# Altium schematic sheet 5.04.51805A02_STMMCU.SchDoc(5.04.51805A02_STMMCU)
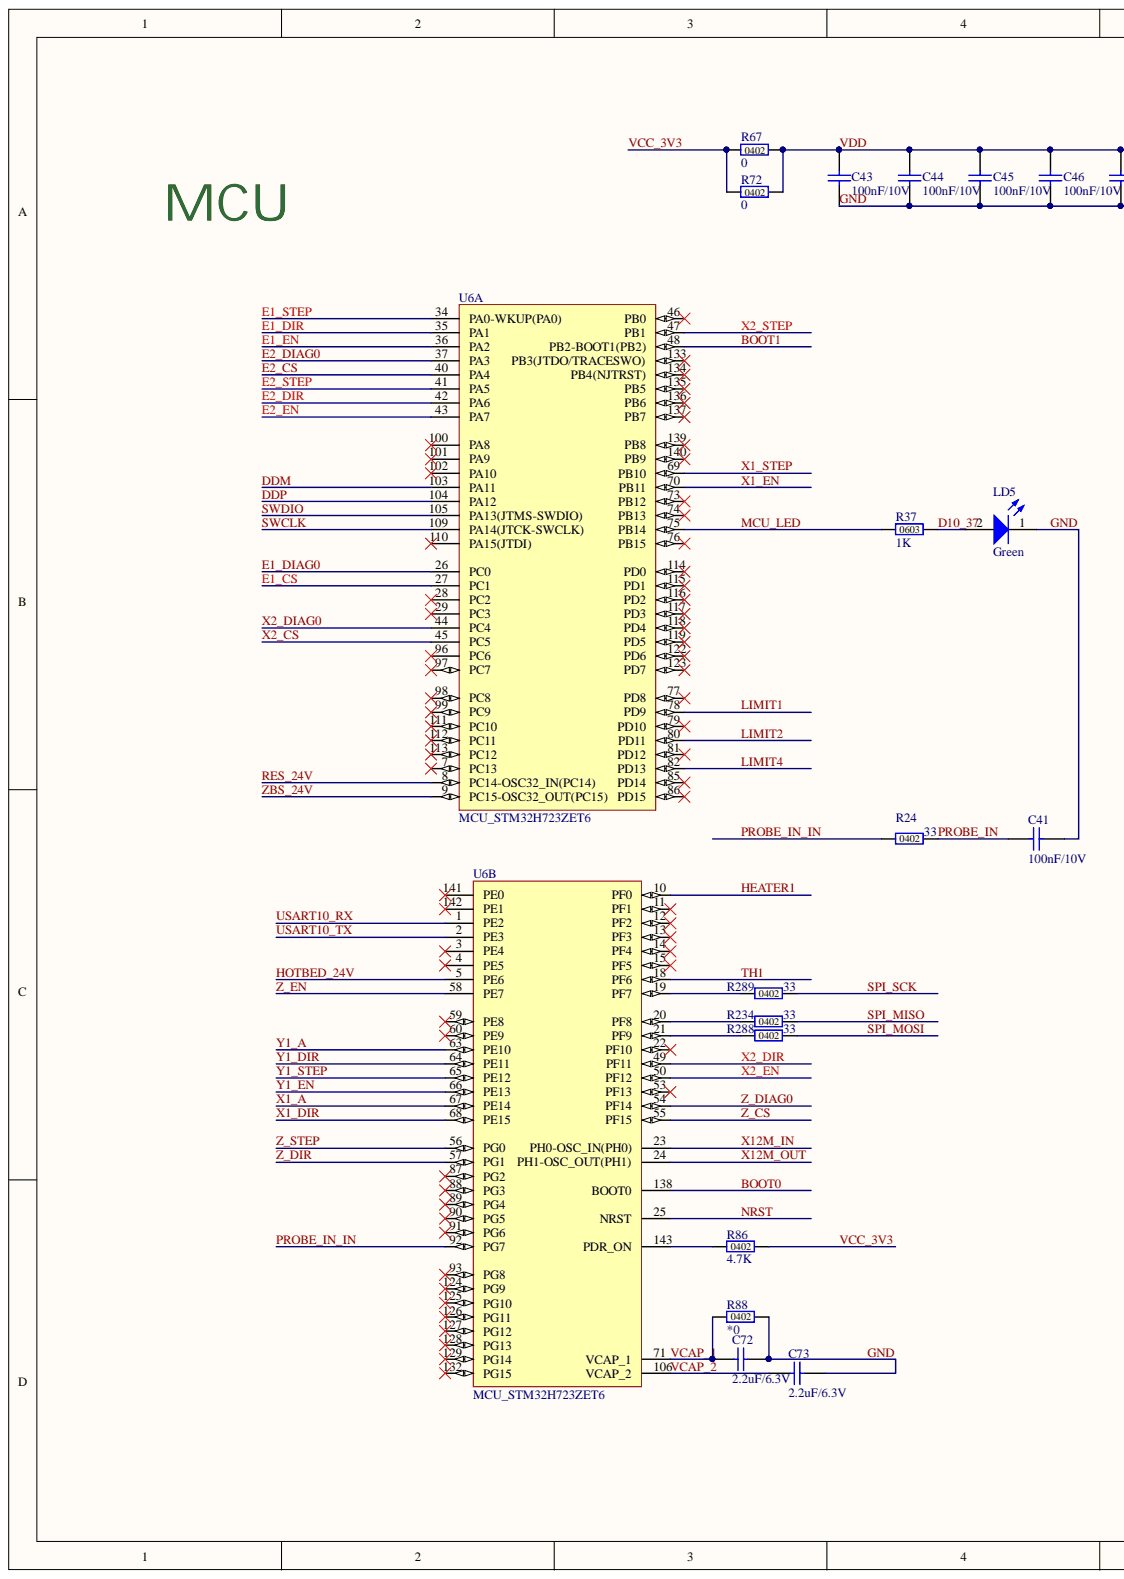
[diagram p0: 5.04.51805A02_STMMCU.SchDoc, left]
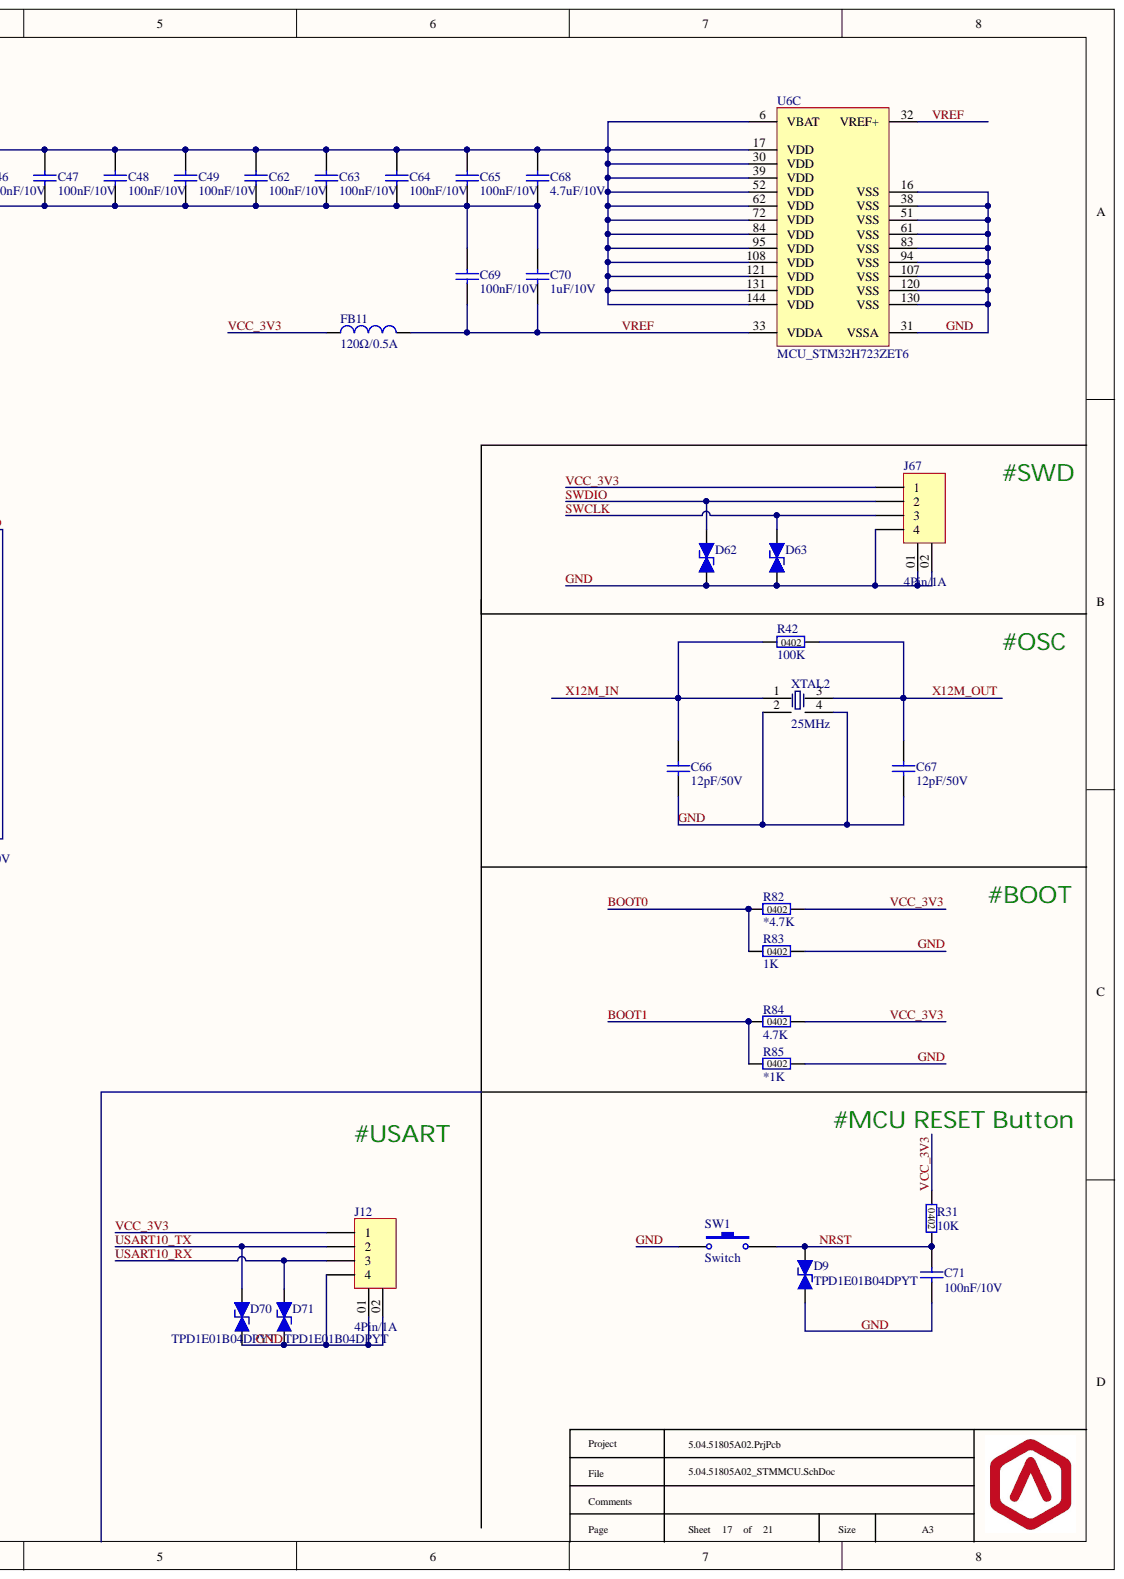
[diagram p1: 5.04.51805A02_STMMCU.SchDoc, right]

## Parts
C41  100nF/10V  [C0402]
C43  100nF/10V  [C0402]
C44  100nF/10V  [C0402]
C45  100nF/10V  [C0402]
C46  100nF/10V  [C0402]
C47  100nF/10V  [C0402]
C48  100nF/10V  [C0402]
C49  100nF/10V  [C0402]
C62  100nF/10V  [C0402]
C63  100nF/10V  [C0402]
C64  100nF/10V  [C0402]
C65  100nF/10V  [C0402]
C66  12pF/50V  [C0603]
C67  12pF/50V  [C0603]
C68  4.7uF/10V  [C0402]
C69  100nF/10V  [C0402]
C70  1uF/10V  [C0402]
C71  100nF/10V  [C0402]
C72  2.2uF/6.3V  [C0402]
C73  2.2uF/6.3V  [C0402]
D9  TPD1E01B04DPYT  [TVS_0402]
D62  TPD1E01B04DPYT  [TVS_0402]
D63  TPD1E01B04DPYT  [TVS_0402]
D70  TPD1E01B04DPYT  [TVS_0402]
D71  TPD1E01B04DPYT  [TVS_0402]
FB11  120Ω/0.5A  [L0402]
J12  4Pin/1A  [BM04B-GHS-TBT(LF)(SN)]
J67  4Pin/1A  [BM04B-GHS-TBT(LF)(SN)]
LD5  Green  [LED0603_2]
R24  33  [R0402]
R31  10K  [R0402]
R37  1K  [R0603]
R42  100K  [R0402]
R67  0  [R0402]
R72  0  [R0402]
R82  *4.7K  [R0402]
R83  1K  [R0402]
R84  4.7K  [R0402]
R85  *1K  [R0402]
R86  4.7K  [R0402]
R88  *0  [R0402]
R234  33  [R0402]
R288  33  [R0402]
R289  33  [R0402]
SW1  Switch  [TS-021]
U6  MCU_STM32H723ZET6  [LQFP144_N]  (parts A,B,C)
XTAL2  25MHz  [XTAL_24MHz]

## Nets
BOOT0: R82.1 R83.1 U6.138(BOOT0)
BOOT1: R84.1 R85.1 U6.48(PB2-BOOT1(PB2))
D10_37: LD5.2 R37.2
DDM: U6.103(PA11)
DDP: U6.104(PA12)
E1_CS: U6.27(PC1)
E1_DIAG0: U6.26(PC0)
E1_DIR: U6.35(PA1)
E1_EN: U6.36(PA2)
E1_STEP: U6.34(PA0-WKUP(PA0))
E2_CS: U6.40(PA4)
E2_DIAG0: U6.37(PA3)
E2_DIR: U6.42(PA6)
E2_EN: U6.43(PA7)
E2_STEP: U6.41(PA5)
GND: C41.1 C43.1 C44.1 C45.1 C46.1 C47.1 C48.1 C49.1 C62.1 C63.1 C64.1 C65.1 C66.1 C67.1 C68.1 C69.2 C70.2 C71.1 C72.1 C73.1 D9.1 D62.1 D63.1 D70.1 D71.1 J12.01 J12.02 J12.4 J67.01 J67.02 J67.4 LD5.1 R83.2 R85.2 R88.2 SW1.1 U6.16(VSS) U6.31(VSSA) U6.38(VSS) U6.51(VSS) U6.61(VSS) U6.83(VSS) U6.94(VSS) U6.107(VSS) U6.120(VSS) U6.130(VSS) XTAL2.2 XTAL2.4
HEATER1: U6.10(PF0)
HOTBED_24V: U6.5(PE6)
LIMIT1: U6.78(PD9)
LIMIT2: U6.80(PD11)
LIMIT4: U6.82(PD13)
MCU_LED: R37.1 U6.75(PB14)
NRST: C71.2 D9.2 R31.2 SW1.2 U6.25(NRST)
PROBE_IN: C41.2 R24.2
PROBE_IN_IN: R24.1 U6.92(PG7)
RES_24V: U6.8(PC14-OSC32_IN(PC14))
SPI_MISO: R234.2
SPI_MOSI: R288.2
SPI_SCK: R289.2
SWCLK: D63.2 J67.3 U6.109(PA14(JTCK-SWCLK))
SWDIO: D62.2 J67.2 U6.105(PA13(JTMS-SWDIO))
TH1: U6.18(PF6)
USART10_RX: D71.2 J12.3 U6.1(PE2)
USART10_TX: D70.2 J12.2 U6.2(PE3)
VCAP_1: C72.2 R88.1 U6.71(VCAP_1)
VCAP_2: C73.2 U6.106(VCAP_2)
VCC_3V3: FB11.1 J12.1 J67.1 R31.1 R67.1 R72.1 R82.2 R84.2 R86.2
VDD: C43.2 C44.2 C45.2 C46.2 C47.2 C48.2 C49.2 C62.2 C63.2 C64.2 C65.2 C68.2 R67.2 R72.2 U6.6(VBAT) U6.17(VDD) U6.30(VDD) U6.39(VDD) U6.52(VDD) U6.62(VDD) U6.72(VDD) U6.84(VDD) U6.95(VDD) U6.108(VDD) U6.121(VDD) U6.131(VDD) U6.144(VDD)
VREF: C69.1 C70.1 FB11.2 U6.32(VREF+) U6.33(VDDA)
X1_A: U6.67(PE14)
X1_DIR: U6.68(PE15)
X1_EN: U6.70(PB11)
X1_STEP: U6.69(PB10)
X2_CS: U6.45(PC5)
X2_DIAG0: U6.44(PC4)
X2_DIR: U6.49(PF11)
X2_EN: U6.50(PF12)
X2_STEP: U6.47(PB1)
X12M_IN: C66.2 R42.1 U6.23(PH0-OSC_IN(PH0)) XTAL2.1
X12M_OUT: C67.2 R42.2 U6.24(PH1-OSC_OUT(PH1)) XTAL2.3
Y1_A: U6.63(PE10)
Y1_DIR: U6.64(PE11)
Y1_EN: U6.66(PE13)
Y1_STEP: U6.65(PE12)
Z_CS: U6.55(PF15)
Z_DIAG0: U6.54(PF14)
Z_DIR: U6.57(PG1)
Z_EN: U6.58(PE7)
Z_STEP: U6.56(PG0)
ZBS_24V: U6.9(PC15-OSC32_OUT(PC15))
NetR86_1: R86.1 U6.143(PDR_ON)
NetR234_1: R234.1 U6.20(PF8)
NetR288_1: R288.1 U6.21(PF9)
NetR289_1: R289.1 U6.19(PF7)
N00843:

Not connected: U6 3(PE4) 4(PE5) 7(PC13) 11(PF1) 12(PF2) 13(PF3) 14(PF4) 15(PF5) 22(PF10) 28(PC2) 29(PC3) 46(PB0) 53(PF13) 59(PE8) 60(PE9) 73(PB12) 74(PB13) 76(PB15) 77(PD8) 79(PD10) 81(PD12) 85(PD14) 86(PD15) 87(PG2) 88(PG3) 89(PG4) 90(PG5) 91(PG6) 93(PG8) 96(PC6) 97(PC7) 98(PC8) 99(PC9) 100(PA8) 101(PA9) 102(PA10) 110(PA15(JTDI)) 111(PC10) 112(PC11) 113(PC12) 114(PD0) 115(PD1) 116(PD2) 117(PD3) 118(PD4) 119(PD5) 122(PD6) 123(PD7) 124(PG9) 125(PG10) 126(PG11) 127(PG12) 128(PG13) 129(PG14) 132(PG15) 133(PB3(JTDO/TRACESWO)) 134(PB4(NJTRST)) 135(PB5) 136(PB6) 137(PB7) 139(PB8) 140(PB9) 141(PE0) 142(PE1)

## Library data of the parts
C41 C43-C49 C62-C65 C69 C71 = C0402_100nF_10V: Ceramic Capacitor, 100nF, ±10%, 10V, -55°C~125°C, C0402; Manufacturer1: MuRata; ManufacturerPartNumber1: GRM155R71A104KA01D; Code: 5161C104; Component Kind: Standard; Library Name: Raise3D_Capacitor.IntLib
C66 C67 = C0603_10pF_50V: Ceramic Capacitor, 12pF, ±5%, 50V, -55°C~125°C, C0603; Manufacturer1: FH; ManufacturerPartNumber1: 0603CG120J500NT; Code: 5192C100; Component Kind: Standard; Library Name: Raise3D_Capacitor.IntLib
C68 = C0402_4.7uF_10V: Ceramic Capacitor, 4.7uF, ±20%, 10V, -55°C~85°C, C0402; Manufacturer1: MuRata; ManufacturerPartNumber1: GRM155R61A475MEAAD; Code: 5161C475; Component Kind: Standard; Library Name: Raise3D_Capacitor.IntLib
C70 = C0402_1uF_10V: Ceramic Capacitor, 1uF, ±10%, 10V, -55°C~125°C, C0402; Manufacturer1: Yageo; ManufacturerPartNumber1: CC0402KRX5R6BB105; Code: 5161C105; Component Kind: Standard; Library Name: Raise3D_Capacitor.IntLib
C72 C73 = C0402_2.2uF_6.3V: Ceramic Capacitor, 2.2uF, ±10%, 6.3V, -55°C~85°C, C0402; Manufacturer1: Yageo; ManufacturerPartNumber1: CC0402KRX5R5BB225; Code: 5151C225; Component Kind: Standard; Library Name: Raise3D_Capacitor.IntLib
D9 D62 D63 D70 D71 = TVS_TPD1E01B04DPYT: TVS二极管,TPD1E01B04DPYT,3.6V,1Channel,+/-17kV,+/-15kV,X1SON-2,-40°C~125°C; Manufacturer1: TexasInstruments; Code: 53040002; Component Kind: Standard; ManufacturerPartNumber1: TPD1E01B04DPYT
  D9 D70 D71: Library Name: Raise3D_Diode.IntLib
  D62 D63: Library Name: Raise3D_Diode.SCHLIB
FB11 = Bead_120Ohm_0.5A: Bead,BLM15AG121SN1D,120Ohm,0.5A,25%,L0402,-55°C~125°C; Manufacturer1: Murata; Code: 52010001; Component Kind: Standard; ManufacturerPartNumber1: BLM15AG121SN1D; Library Name: Raise3D_Inductor&Bead.IntLib
J12 J67 = JW_BM04B-GHS-TBT(LF)(SN): 线对板连接器,4PINS,Pitch1.25mm,SMT,OR,1A,象牙白,-25°C~85°C; Manufacturer1: JST; Code: 55010003; Component Kind: Standard; Manufacturer2: 健坤; ManufacturerPartNumber1: BM04B-GHS-TBT(LF)(SN); ManufacturerPartNumber2: A1253AWV-04-F1CB1-P; Library Name: Raise3D_Connector.IntLib
LD5 = LED_APT1608CGCK: LED,APT1608CGCK,Green,2.5V,TOP,LED0603,-40°C~85°C; Manufacturer1: Kingbright; Code: 53010003; Component Kind: Standard; ManufacturerPartNumber1: APT1608CGCK; Library Name: Raise3D_Diode.IntLib
R24 R234 R288 R289 = R0402_33: Resistor 33ohm,1%,1/16W,R0402; Manufacturer1: Yageo; ManufacturerPartNumber1: RC0402FR-0733RL; Code: 5001033R; Component Kind: Standard; Library Name: Raise3D_Resistor.IntLib
R31 = R0402_10K: Resistor 10Kohm,1%,1/16W,R0402; Manufacturer1: Yageo; ManufacturerPartNumber1: RC0402FR-0710KL; Code: 5001010K; Component Kind: Standard; Manufacturer2: Samsung; ManufacturerPartNumber2: RC1005F103CS; Library Name: Raise3D_Resistor.SCHLIB
R37 = R0603_1K: Resistor  1Kohm,1%,1/10W,R0603; Manufacturer1: Yageo; ManufacturerPartNumber1: RC0603FR-071KL; Code: 5002001K; Component Kind: Standard; Library Name: Raise3D_Resistor.IntLib
R42 = R0402_100K: Resistor 100Kohm,1%,1/16W,R0402; Manufacturer1: Yageo; ManufacturerPartNumber1: RC0402FR-07100KL; Code: 5001100K; Component Kind: Standard; Manufacturer2: Samsung; ManufacturerPartNumber2: RC1005F104CS; Library Name: Raise3D_Resistor.IntLib
R67 R72 R88 = R0402_0: Resistor 0ohm,1%,1/16W,R0402; Manufacturer1: Yageo; ManufacturerPartNumber1: RC0402FR-070RL; Code: 5001000R; Component Kind: Standard; Library Name: Raise3D_Resistor.IntLib
R82 R84 R86 = R0402_4.7K: Resistor 4.7Kohm,5%,1/16W,R0402; Manufacturer1: Yageo; ManufacturerPartNumber1: RC0402JR-074K7L; Code: 501104K7; Component Kind: Standard; Manufacturer2: Samsung; ManufacturerPartNumber2: RCS1005J472CS; Library Name: Raise3D_Resistor.IntLib
R83 R85 = R0402_1K: Resistor 1Kohm,5%,1/16W,R0402; Manufacturer1: Yageo; ManufacturerPartNumber1: RC0402JR-071KL; Code: 5011001K; Component Kind: Standard; Library Name: Raise3D_Resistor.IntLib
SW1 = B_TS-021-250G: 开关,TS-021,DC12V50mA,30mOhm,SPST,垂直,SMT,-30°C~70°C; Manufacturer1: 东莞合智电子; Code: 57010003; Component Kind: Standard; ManufacturerPartNumber1: TS-021-250G; Library Name: Raise3D_Switch&Optocoupler.IntLib
U6 = MCU_STM32H723ZET6: ARM Cortex-M7 32-bit MCU+FPU, 1177DMIPS, 1MB Flash, 564kB Internal RAM, 114 I/Os, 144-pin LQFP, -40 to 85 degC, Tray; Manufacturer1: STM; Code: 590100XX; Component Kind: Standard; ManufacturerPartNumber1: STM32H723ZET6; Library Name: Raise3D_IC.IntLib
XTAL2 = XTAL_25MHz_00: Crystal,GL327S250L,25MHz,12pF,20Ohm,4-SMD,3.2mmX2.5mm,-40°C~105°C; Manufacturer1: Lucki Electronics; code: 58010008; Component Kind: Standard; Manufacturer2: YXC; ManufacturerPartNumber1: GL327S250L; ManufacturerPartNumber2: X322525MOB4SI; Library Name: Raise3D_Crystal.IntLib
